# Altium schematic sheet Sheet2.SchDoc
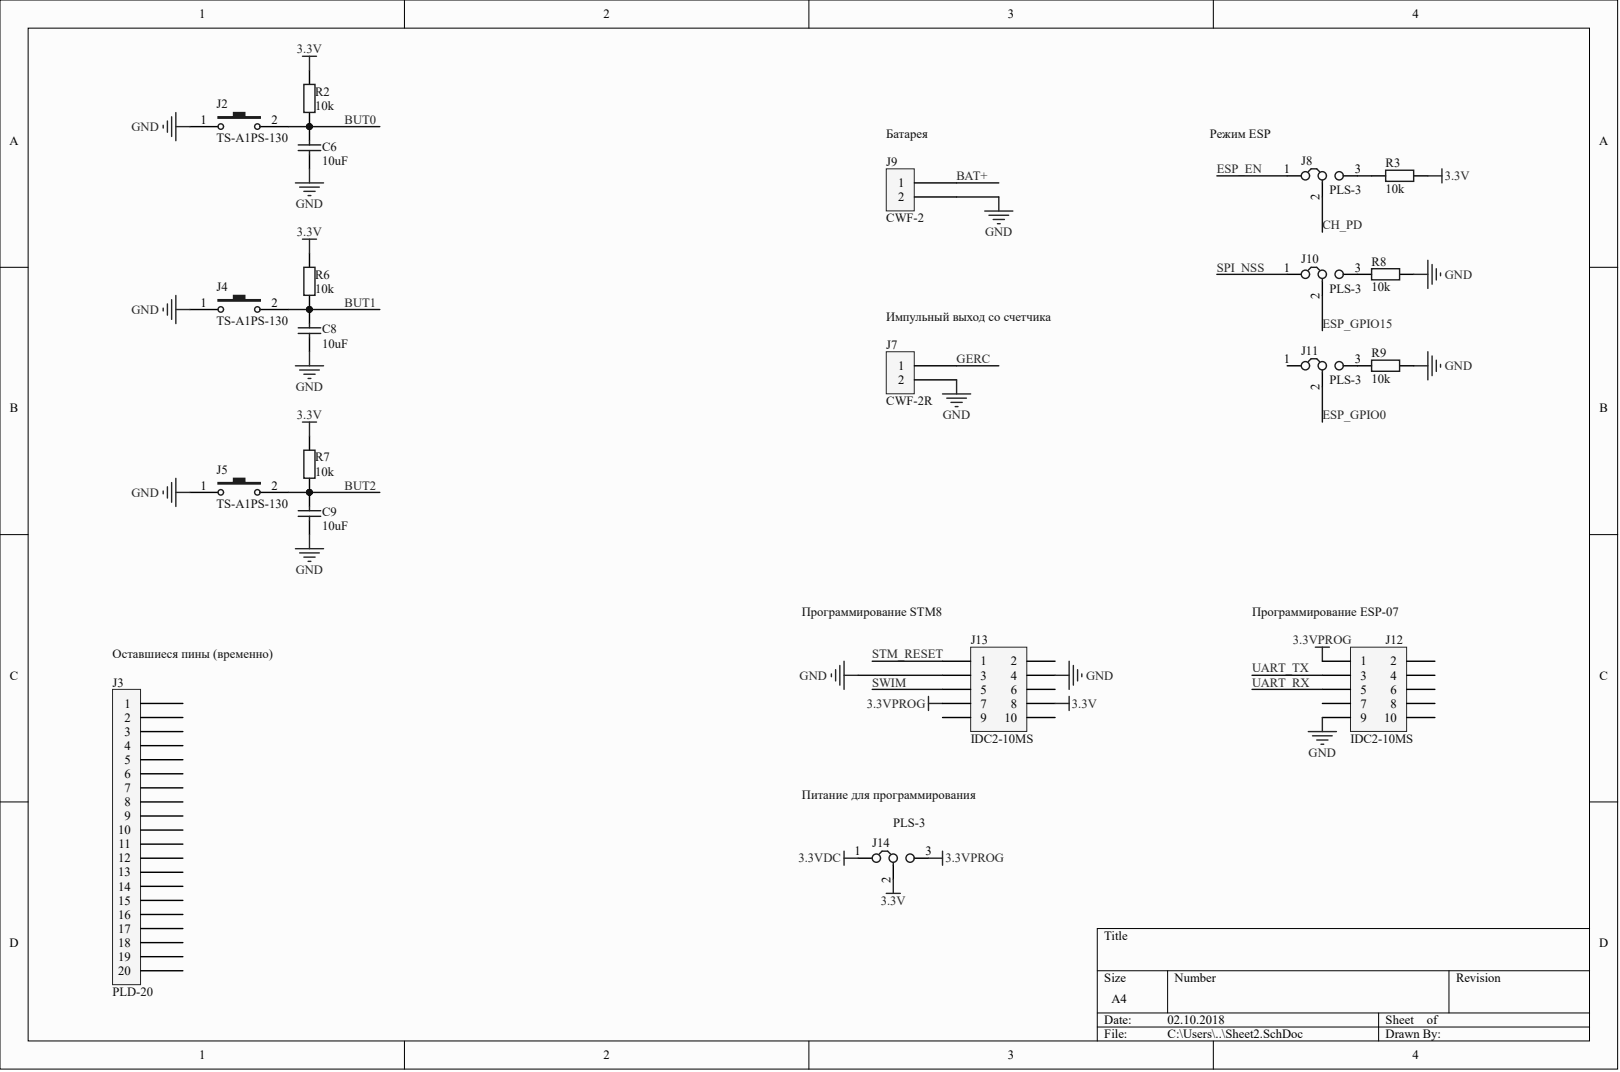
[diagram p0: Sheet2.SchDoc]

## Parts
C6  value 10uF  (hidden comment: Capacitor)
C8  value 10uF  (hidden comment: Capacitor)
C9  value 10uF  (hidden comment: Capacitor)
J2  TS-A1PS-130
J3  PLD-20
J4  TS-A1PS-130
J5  TS-A1PS-130
J7  CWF-2R
J8  PLS-3
J9  CWF-2
J10  PLS-3
J11  PLS-3
J12  IDC2-10MS
J13  IDC2-10MS
J14  PLS-3
R2  value 10k  (hidden comment: Resistor)
R3  value 10k  (hidden comment: Resistor)
R6  value 10k  (hidden comment: Resistor)
R7  value 10k  (hidden comment: Resistor)
R8  value 10k  (hidden comment: Resistor)
R9  value 10k  (hidden comment: Resistor)

## Nets
3.3V: J13.8 J14.2 R2.2 R3.2 R6.2 R7.2
3.3VDC: J14.1
3.3VPROG: J12.1 J13.7 J14.3
GND: C6.1 C8.1 C9.1 J2.1 J4.1 J5.1 J7.2 J9.2 J12.9 J13.3 J13.4 R8.2 R9.2
BAT+: J9.1
BUT0: C6.2 J2.2 R2.1
BUT1: C8.2 J4.2 R6.1
BUT2: C9.2 J5.2 R7.1
CH_PD: J8.2
ESP_EN: J8.1
ESP_GPIO0: J11.2
ESP_GPIO15: J10.2
GERC: J7.1
SPI_NSS: J10.1
STM_RESET: J13.1
SWIM: J13.5
UART_RX: J12.5
UART_TX: J12.3
NetJ8_3: J8.3 R3.1
NetJ10_3: J10.3 R8.1
NetJ11_3: J11.3 R9.1

Not connected: J3 1 2 3 4 5 6 7 8 9 10 11 12 13 14 15 16 17 18 19 20; J11 1; J12 2 4 6 7 8 10; J13 2 6 9 10

## Library data of the parts
C6 C8 C9 = (no symbol name): Name: Конденсатор керамический SMD
J2 J4 J5 = (no symbol name): Name: Кнопка тактовая TS-A1PS-130
J8 J10 J11 J14 = (no symbol name): Name: Вилка штыревая PLS-3, прямая
J12 J13 = (no symbol name): Name: Вилка на плату IDC2-10MS, прямая
R2 R3 R6-R9 = (no symbol name): Name: Резистор SMD
